# Altium schematic sheet Airbag STM32v3.SchDoc
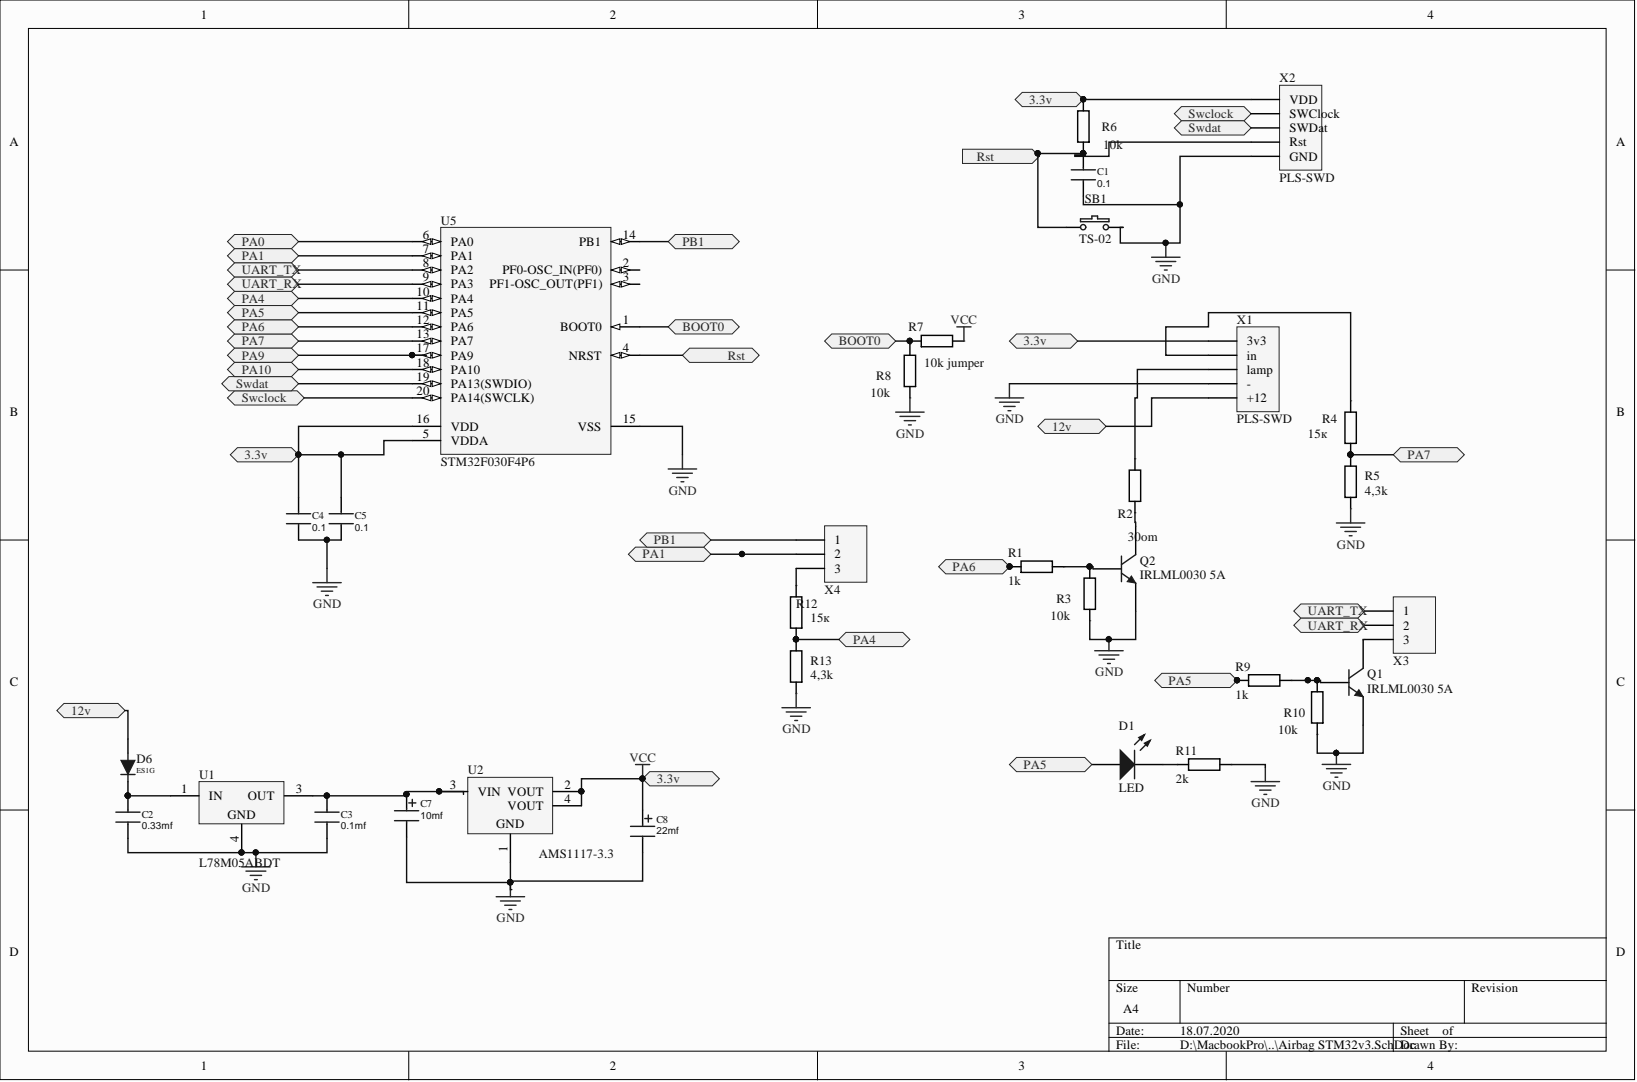
[diagram p0: Airbag STM32v3.SchDoc]

## Parts
C1  0.1  [C_0805]
C2  0.33mf  [C_0805]
C3  0.1mf  [C_0805]
C4  0.1  [C_0805]
C5  0.1  [C_0805]
C7  10mf  [CPM3528]
C8  22mf  [CPM3528]
D1  LED  [3.2X1.6X1.1]
D6  ES1G  [DO-214AC (SMA)]
Q1  IRLML0030 5A  [SOT23_N]
Q2  IRLML0030 5A  [SOT23_N]
R1  1k  [Resistor 0805]
R2  30om  [Resistor 0805]
R3  10k  [Resistor 0805]
R4  15к  [Resistor 0805]
R5  4,3k  [Resistor 0805]
R6  10k  [Resistor 0805]
R7  10k jumper  [Resistor 0805]
R8  10k  [Resistor 0805]
R9  1k  [Resistor 0805]
R10  10k  [Resistor 0805]
R11  2k  [Resistor 0805]
R12  15к  [Resistor 0805]
R13  4,3k  [Resistor 0805]
SB1  TS-02  [TS-02]
U1  L78M05ABDT  [TO-252]
U2  AMS1117-3.3  [TI-DCY4_N]
U5  STM32F030F4P6  [STM-TSSOP20_M]
X1  PLS-SWD  [PLS-5-3D]
X2  PLS-SWD  [PLS-5-3D]
X3  PLS-3  [PLS-3-3D]  (value not drawn)
X4  PLS-3  [PLS-3-3D]  (value not drawn)

## Ports
3.3V, 12V, BOOT0, PA0, PA1, PA4, PA5, PA6, PA7, PA9, PA10, PB1, RST, SWCLOCK, SWDAT, UART_RX, UART_TX

## Nets
GND: C1.1 C2.1 C3.1 C4.2 C5.2 C7.2 C8.2 Q1.2 Q2.2 R3.1 R5.1 R8.1 R10.1 R11.1 R13.1 SB1.2 U1.4 U2.1 U5.15(VSS) X1.4(-) X2.5(GND)
VCC: C4.1 C5.1 C8.1 R6.2 R7.2 U2.2(VOUT) U2.4(VOUT) U5.5(VDDA) U5.16(VDD) X1.1(3v3) X2.1(VDD) ; port 3.3V
BOOT0: R7.1 R8.2 U5.1(BOOT0) ; port BOOT0
PA0: U5.6(PA0) ; port PA0
PA1: U5.7(PA1) X4.2 ; port PA1
PA4: R12.1 R13.2 U5.10(PA4) ; port PA4
PA5: D1.1 R9.1 U5.11(PA5) ; port PA5
PA6: R1.1 U5.12(PA6) ; port PA6
PA7: R4.1 R5.2 U5.13(PA7) ; port PA7
PA9: U5.17(PA9) ; port PA9
PA10: U5.18(PA10) ; port PA10
PB1: U5.14(PB1) X4.1 ; port PB1
UART_RX: U5.9(PA3) X3.2 ; port UART_RX
UART_TX: U5.8(PA2) X3.1 ; port UART_TX
NetC1_2: C1.2 R6.1 SB1.1 U5.4(NRST) X2.4(Rst) ; port RST
NetC2_2: C2.2 D6.2 U1.1
NetC3_2: C3.2 C7.1 U1.3 U2.3(VIN)
NetD1_2: D1.2 R11.2
NetD6_1: D6.1 X1.5(+12) ; port 12V
NetQ1_1: Q1.1 R9.2 R10.2
NetQ1_3: Q1.3 X3.3
NetQ2_1: Q2.1 R1.2 R3.2
NetQ2_3: Q2.3 R2.1
NetR2_2: R2.2 X1.3(lamp)
NetR4_2: R4.2 X1.2(in)
NetR12_2: R12.2 X4.3
NetU5_19: U5.19(PA13(SWDIO)) X2.3 ; port SWDAT
NetU5_20: U5.20(PA14(SWCLK)) X2.2 ; port SWCLOCK

Not connected: U5 2(PF0-OSC_IN(PF0)) 3(PF1-OSC_OUT(PF1))

## Library data of the parts
C1-C5 = C1206: Capacitor; Component Kind: Standard; Library Name: AX_Altium_v2.3.IntLib
  C1 C4 C5: Value: 0.1
  C2: Value: 0.33mf
  C3: Value: 0.1mf
C7 C8 = CPM3528: Capacitor; Component Kind: Standard; Library Name: AX_Altium_v2.3.IntLib
  C7: Value: 10mf
  C8: Value: 22mf
D1 = LED2: Typical RED, GREEN, YELLOW, AMBER GaAs LED; Component Kind: Standard; LatestRevisionDate: 17-Jul-2002; LatestRevisionNote: Re-released for DXP Platform.; Library Name: Miscellaneous Devices.IntLib; PackageDescription: SM LED; 2 Flat Leads; PackageReference: 3.2X1.6X1.1; PackageVersion: Feb-2002; Published: 8-Jun-2000; Simulation: LED2; Publisher: Altium Limited
D6 = ES1G: SMD SMA 1A 400V 35nS; Component Kind: Standard; Library Name: Diodes-Power.IntLib; Price: 0.09; Signal Integrity: D
Q1 Q2 = BF547: NPN 1 GHz Wideband Transistor; ComponentAvailability: Obsolete; Component Kind: Standard; ComponentLink1Description: Manufacturer Link; ComponentLink1URL: http://www.semiconductors.philips.com/; ComponentLink2Description: Datasheet; ComponentLink2URL: http://www.semiconductors.philips.com/acrobat/datasheets/BF547_3.pdf; ComponentLink3Description: Discontinuation Notice; ComponentLink3URL: http://www.semiconductors.philips.com/pip/old/BF547.html; DatasheetVersion: Sep-1995; LatestRevisionDate: 12-Dec-2006; LatestRevisionNote: IPC-7351 Footprint Added.; Library Name: Philips Discrete BJT - RF Transistor.IntLib; PackageDescription: 3-Pin SOT-23 Package 0.95 mm Pitch, 2.3 mm Lead Span; PackageReference: SOT23; PackageVersion: May-1999; Published: 17-Sep-2003; Signal Integrity: BF547; Simulation: BF547; Publisher: Altium Limited
R1-R13 = Resistor: Component Kind: Standard
  R1-R5 R7-R10 R12 R13: Library Name: ILBIL.IntLib
  R6 R11: Library Name: Maks_lib.IntLib
SB1 = Buton TS-02: Component Kind: Standard; Library Name: Devise.IntLib; Price: 0.1
U1 = L78M05ABDT: Precision 500mA Regulator; Component Kind: Standard; ComponentLink1Description: Manufacturer Link; ComponentLink1URL: http://www.st.com/; ComponentLink2Description: Datasheet; ComponentLink2URL: http://www.st.com/stonline/products/literature/ds/2147/l78m05ab.pdf; DatasheetVersion: Mar-1997; LatestRevisionDate: 06-Jun-2005; LatestRevisionNote: PCB pads lengthened.; Library Name: ST Power Mgt Voltage Regulator.IntLib; PackageDescription: D-PAK/TO-252-AA; 3 Leads (1 Cropped); Body 10.1 (inc. leads) x 6.6 mm (LxW max); PackageReference: TO-252; PackageVersion: Jun-2004; Published: 24-Aug-2001; Publisher: Altium Limited
U2 = REG1117-3.3G4: Single Output LDO, 800 mA, Fixed 3.3 V Output, 3.8 to 15 V Input, with Internal Current Limiting, 4-pin SOT-223 (DCY), -40 to 125 degC, Green (RoHS & no Sb/Br); Manufacturer: Texas Instruments; Fixed Output Options(V): 5; Iout(Max)(A): 0.8; Iq(Typ)(mA): 4; Rating: Catalog; SubFamily: Single Channel LDO; Vdo(Typ)(mV): 1100; Vin(Max)(V): 15; Vin(Min)(V): 3.8; Vout Adj(Max)(V): 3.3; Code_JEDEC: TO-261; Component Kind: Standard; ComponentLink1Description: Manufacturer URL; ComponentLink1URL: http://www.ti.com/; ComponentLink2Description: Datasheet; ComponentLink2URL: http://www.ti.com/general/docs/lit/getliterature.tsp?genericPartNumber=REG1117-33&fileType=pdf; ComponentLink3Description: Package Specification; ComponentLink3URL: http://www.ti.com/litv/pdf/mpds094a; DatasheetVersion: SBVS001D; Eco-Plan: Green (RoHS & no Sb/Br); Library Name: TI Power Management Linear Regulator Single Channel LDO (400-7500mA).IntLib; Mounting Technology: Surface Mount; PackageDescription: 4-Pin Plastic Small Outline, Body 3.5 x 6.5 mm, Pitch 2.3 mm; PackageReference: DCY4; PackageVersion: 4202506/B; PartNumber: REG1117-3.3G4; Supplier 1: Digi-Key; Supplier 2: Newark; Supplier 3: Mouser; Supplier Part Number 1: REG1117-3.3G4-ND; Supplier Part Number 2: 28M6744; Supplier Part Number 3: 595-REG1117-3.3G4; Vout Adj(Min)(V): 3.3
U5 = STM32F030F4P6: ARM Cortex-M0 32-bit MCU, 16 KB Flash, 4 KB Internal RAM, 15 I/Os, 20-pin TSSOP, -40 to 85 degC, Tube; FLASH Size (Prog) (kB): 16; I/Os (High Current): 15; Internal RAM Size (kB): 4; Operating Frequency(F) (Processor speed) (MHz): 48; Supply Voltage(Vcc) min (V): 2.4; Component Kind: Standard; ComponentLink1Description: Manufacturer URL; ComponentLink1URL: http://www.st.com/web/en/home.html; ComponentLink2Description: Datasheet; ComponentLink2URL: http://www.st.com/st-web-ui/static/active/en/resource/technical/document/datasheet/DM00088500.pdf; ComponentLink3Description: Package Specification; ComponentLink3URL: http://www.st.com/st-web-ui/static/active/en/resource/technical/document/datasheet/DM00065136.pdf; Core: ARM Cortex-M0; DatasheetVersion: Rev. 1; Library Name: STMicroelectronics STM32 F0.IntLib; Mounting Technology: Surface Mount; PackageDescription: 20-Pin Thin Shrink Small Outline Package, 6.5 x 4.4 mm Body, 0.65 mm Pitch; PackageReference: TSSOP20; PackageVersion: Rev. 1, 11/2012; Packing: Tube; PartNumber: STM32F030F4P6; Supplier 1: Digi-Key; Supplier 2: Newark; Supplier 3: Mouser; Supplier Part Number 1: 497-14044-5-ND; Supplier Part Number 2: 06X3648; Supplier Part Number 3: 511-STM32F030F4P6
X1 X2 = PLS-5: Header, 5-Pin; Component Kind: Standard; LatestRevisionDate: 17-Jul-2002; LatestRevisionNote: Re-released for DXP Platform.; Library Name: AX_Altium_v2.3.IntLib; Publisher: Altium Limited
X3 X4 = PLS-3: Header, 3-Pin; Component Kind: Standard; LatestRevisionDate: 17-Jul-2002; LatestRevisionNote: Re-released for DXP Platform.; Library Name: AX_Altium_v2.3.IntLib; Publisher: Altium Limited
